# Altium schematic sheet ECAT0_(IN).SchDoc(ECAT0_(IN))
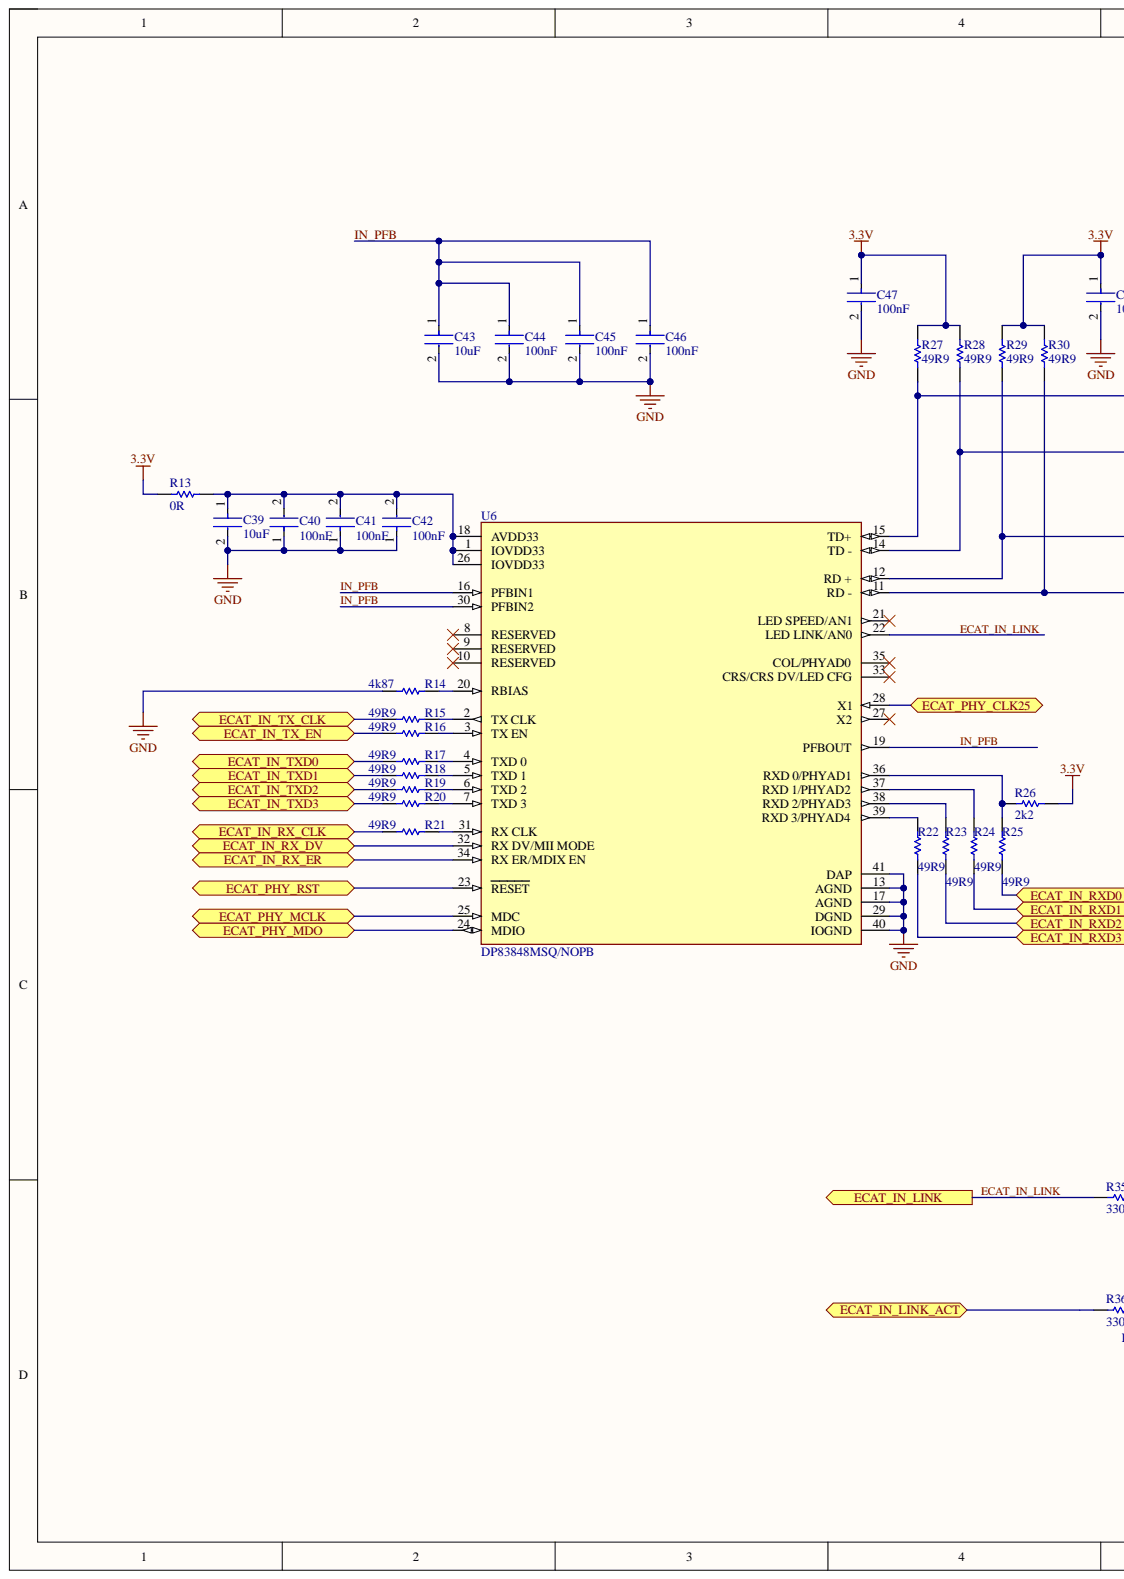
[diagram p0: ECAT0_, left]
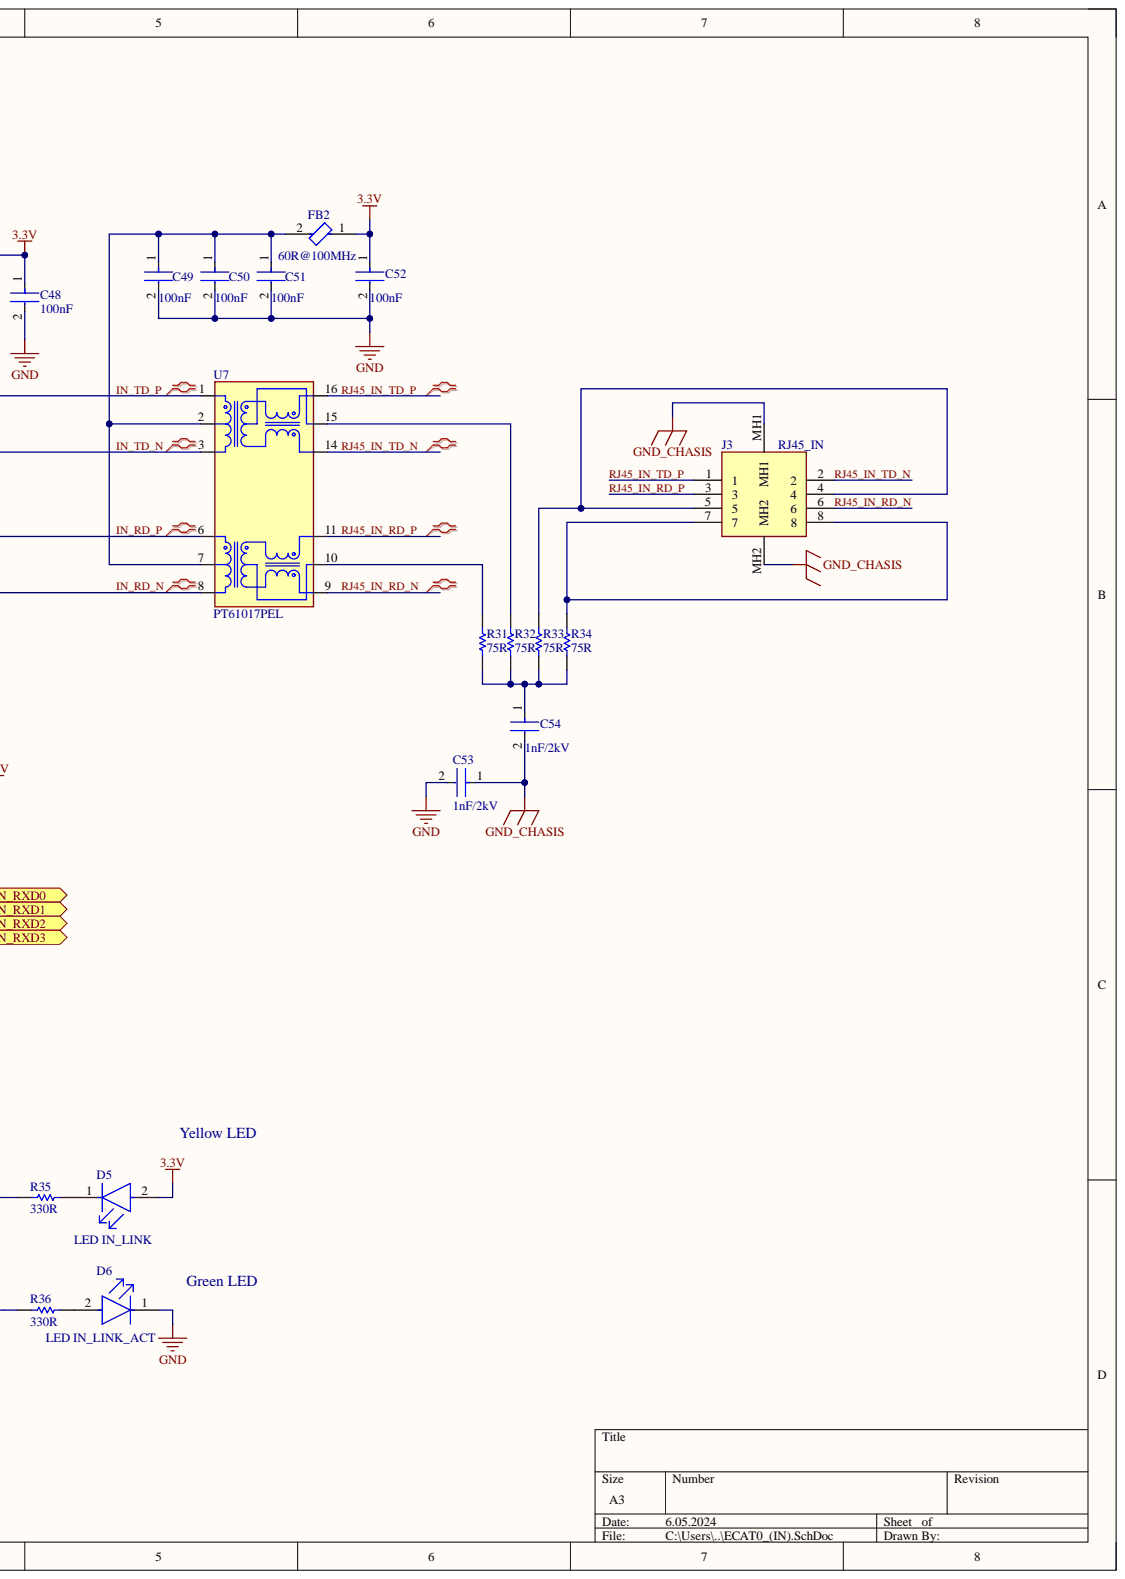
[diagram p1: ECAT0_, right]

## Parts
C39  10uF  [FP-C0805C-DN-MFG]
C40  100nF  [FP-C0805C-DN-MFG]
C41  100nF  [FP-C0805C-DN-MFG]
C42  100nF  [FP-C0805C-DN-MFG]
C43  10uF  [FP-C0805C-DN-MFG]
C44  100nF  [FP-C0805C-DN-MFG]
C45  100nF  [FP-C0805C-DN-MFG]
C46  100nF  [FP-C0805C-DN-MFG]
C47  100nF  [FP-C0805C-DN-MFG]
C48  100nF  [FP-C0805C-DN-MFG]
C49  100nF  [FP-C0805C-DN-MFG]
C50  100nF  [FP-C0805C-DN-MFG]
C51  100nF  [FP-C0805C-DN-MFG]
C52  100nF  [FP-C0805C-DN-MFG]
C53  1nF/2kV  [FP-CC1206-0_3-1_45-IPC_C]
C54  1nF/2kV  [FP-CC1206-0_3-1_45-IPC_C]
D5  LED IN_LINK  [FP-LTST-C191GKT-MFG]
D6  LED IN_LINK_ACT  Color: Green  [FP-LTST-C191GKT-MFG]
FB2  60R@100MHz  [FP-BLM18-0_15-t0_8-IPC_B]
J3  RJ45_IN  [438600010]
R13  0R  [RESC1609X50X30NL8T20]
R14  4k87  [RESC2013X50X30LL20T20]
R15  49R9  [RESC2013X50X30LL20T20]
R16  49R9  [RESC2013X50X30LL20T20]
R17  49R9  [RESC2013X50X30LL20T20]
R18  49R9  [RESC2013X50X30LL20T20]
R19  49R9  [RESC2013X50X30LL20T20]
R20  49R9  [RESC2013X50X30LL20T20]
R21  49R9  [RESC2013X50X30LL20T20]
R22  49R9  [RESC2013X50X30LL20T20]
R23  49R9  [RESC2013X50X30LL20T20]
R24  49R9  [RESC2013X50X30LL20T20]
R25  49R9  [RESC2013X50X30LL20T20]
R26  2k2  [RESC2013X50X30LL20T20]
R27  49R9  [RESC2013X50X30LL20T20]
R28  49R9  [RESC2013X50X30LL20T20]
R29  49R9  [RESC2013X50X30LL20T20]
R30  49R9  [RESC2013X50X30LL20T20]
R31  75R  [RESC2013X50X30LL20T20]
R32  75R  [RESC2013X50X30LL20T20]
R33  75R  [RESC2013X50X30LL20T20]
R34  75R  [RESC2013X50X30LL20T20]
R35  330R  [RESC2013X50X30LL20T20]
R36  330R  [RESC2013X50X30LL20T20]
U6  DP83848MSQ/NOPB  [FP-RTA0040A-IPC_B]
U7  PT61017PEL  [PT61017PEL]

## Ports
ECAT_IN_LINK, ECAT_IN_LINK_ACT, ECAT_IN_RX_CLK, ECAT_IN_RX_DV, ECAT_IN_RX_ER, ECAT_IN_RXD0, ECAT_IN_RXD1, ECAT_IN_RXD2, ECAT_IN_RXD3, ECAT_IN_TX_CLK, ECAT_IN_TX_EN, ECAT_IN_TXD0, ECAT_IN_TXD1, ECAT_IN_TXD2, ECAT_IN_TXD3, ECAT_PHY_CLK25, ECAT_PHY_MCLK, ECAT_PHY_MDO, ECAT_PHY_RST

## Nets
3.3V: C47.1 C48.1 C52.1 D5.2 FB2.1 R13.2 R26.1 R27.2 R28.2 R29.2 R30.2
GND: C39.2 C40.1 C41.1 C42.1 C43.2 C44.2 C45.2 C46.2 C47.2 C48.2 C49.2 C50.2 C51.2 C52.2 C53.2 D6.1 R14.1 U6.13(AGND) U6.17(AGND) U6.29(DGND) U6.40(IOGND) U6.41(DAP)
GND_CHASIS: C53.1 C54.2 J3.MH1 J3.MH2
ECAT_IN_LINK: R35.1 U6.22(LED_LINK/AN0) ; port ECAT_IN_LINK
ECAT_IN_LINK_ACT: R36.1 ; port ECAT_IN_LINK_ACT
ECAT_IN_RX_CLK: R21.1 ; port ECAT_IN_RX_CLK
ECAT_IN_RX_DV: U6.32(RX_DV/MII_MODE) ; port ECAT_IN_RX_DV
ECAT_IN_RX_ER: U6.34(RX_ER/MDIX_EN) ; port ECAT_IN_RX_ER
ECAT_IN_RXD0: R25.1 ; port ECAT_IN_RXD0
ECAT_IN_RXD1: R24.1 ; port ECAT_IN_RXD1
ECAT_IN_RXD2: R23.1 ; port ECAT_IN_RXD2
ECAT_IN_RXD3: R22.1 ; port ECAT_IN_RXD3
ECAT_IN_TX_CLK: R15.1 ; port ECAT_IN_TX_CLK
ECAT_IN_TX_EN: R16.1 ; port ECAT_IN_TX_EN
ECAT_IN_TXD0: R17.1 ; port ECAT_IN_TXD0
ECAT_IN_TXD1: R18.1 ; port ECAT_IN_TXD1
ECAT_IN_TXD2: R19.1 ; port ECAT_IN_TXD2
ECAT_IN_TXD3: R20.1 ; port ECAT_IN_TXD3
ECAT_PHY_CLK25: U6.28(X1) ; port ECAT_PHY_CLK25
ECAT_PHY_MCLK: U6.25(MDC) ; port ECAT_PHY_MCLK
ECAT_PHY_MDO: U6.24(MDIO) ; port ECAT_PHY_MDO
ECAT_PHY_RST: U6.23(RESET) ; port ECAT_PHY_RST
IN_PFB: C43.1 C44.1 C45.1 C46.1 U6.16(PFBIN1) U6.19(PFBOUT) U6.30(PFBIN2)
IN_RD_N: R30.1 U6.11(RD_-) U7.8
IN_RD_P: R29.1 U6.12(RD_+) U7.6
IN_TD_N: R28.1 U6.14(TD_-) U7.3
IN_TD_P: R27.1 U6.15(TD+) U7.1
RJ45_IN_RD_N: J3.6 U7.9
RJ45_IN_RD_P: J3.3 U7.11
RJ45_IN_TD_N: J3.2 U7.14
RJ45_IN_TD_P: J3.1 U7.16
NetC39_1: C39.1 C40.2 C41.2 C42.2 R13.1 U6.1(IOVDD33) U6.18(AVDD33) U6.26(IOVDD33)
NetC49_1: C49.1 C50.1 C51.1 FB2.2 U7.2 U7.7
NetC54_1: C54.1 R31.1 R32.1 R33.1 R34.1
NetD5_1: D5.1 R35.2
NetD6_2: D6.2 R36.2
NetJ3_4: J3.4 J3.5 R33.2
NetJ3_7: J3.7 J3.8 R34.2
NetR14_2: R14.2 U6.20(RBIAS)
NetR15_2: R15.2 U6.2(TX_CLK)
NetR16_2: R16.2 U6.3(TX_EN)
NetR17_2: R17.2 U6.4(TXD_0)
NetR18_2: R18.2 U6.5(TXD_1)
NetR19_2: R19.2 U6.6(TXD_2)
NetR20_2: R20.2 U6.7(TXD_3)
NetR21_2: R21.2 U6.31(RX_CLK)
NetR22_2: R22.2 U6.39(RXD_3/PHYAD4)
NetR23_2: R23.2 U6.38(RXD_2/PHYAD3)
NetR24_2: R24.2 U6.37(RXD_1/PHYAD2)
NetR25_2: R25.2 R26.2 U6.36(RXD_0/PHYAD1)
NetR31_2: R31.2 U7.10
NetR32_2: R32.2 U7.15

Not connected: U6 8(RESERVED) 9(RESERVED) 10(RESERVED) 21(LED_SPEED/AN1) 27(X2) 33(CRS/CRS_DV/LED_CFG) 35(COL/PHYAD0)

## Library data of the parts
C39-C52 = CMP-2007-00279-2: CAP CER 0.1UF 50V X7R 0805; Manufacturer: KEMET; Manufacturer Part Number: C0805C104K5RACTU; Capacitance: 0.10 μF; Material: Ceramic; Temperature Coefficient: 30ppm/°C; Tolerance: 0.1; Value: 0.10 μF; Automotive: No; Category: Cap; Component Kind: Standard; ComponentLink2Description: Datasheet; ComponentLink2URL: https://content.kemet.com/datasheets/KEM_C1003_C0G_SMD.pdf; Device Class L1: Passive Components; Device Class L2: Capacitors; Device Class L3: Ceramic Capacitors; Digikey Description: CAP CER 0.1UF 50V X7R 0805; Height: 0.88mm; Ipc Land Pattern Name: CAPC200125X78; Lead Free: Yes; Library Name: Altium Content Vault; Mouser Description: Cap Ceramic 0.1uF 50V X7R 10% SMD 2.0 x 1.2 mm 125 C Paper T/R; Octopart: https://octopart.com/c0805c104k5ractu-kemet-143926; Package: C0805C-DN; RoHS: TRUE; Temperature: -55°C to +125°C; Temperature Characteristic: X7R; Temperature Range High: +125°C; Temperature Range Low: -55°C; Voltage Rating: 50 V
C53 C54 = CMP-2000-05436-2: 1nF/2kV/1206; Capacitance: 1nF; Dielectric: X7R; Dissipation Factor: 2.5%; Insulation Resistance: 10GR; Tolerance: 10%; Voltage: 2kV; Case Code (Imperial): 1206; Case Code (Metric): 3216; Case/Package: 1206; Component Kind: Standard; Construction: Flat; Depth: 1.6mm; Height: 1.25mm; Lead Free: Lead Free; Length: 3.2mm; Library Name: Altium Content Vault; Max Operating Temperature: 125°C; Min Operating Temperature: -55°C; Mount: Surface Mount; Number of Pins: 2; Package Quantity: 1; Packaging: Tape and Reel; Radiation Hardening: No; Resistor Type: Ceramic; RoHS Compliant: Yes; Series: CC; Termination: SMD/SMT; Thickness: 0.057inch; Voltage Rating: 2kV; Voltage Rating (DC): 2kV; Width: 0.063inch
D5 = CMP-22017-000029-1: KPHD-1608LVSYCK; Color: Green; Dominant Wavelength: 569nm; Forward Current: 30mA; Forward Voltage: 2.1V; Lens Color: Colorless; Lens Style: Clear; Luminous Intensity: 12mcd; Number of Elements: 1; Power Dissipation: 100mW; Reverse Voltage: 5V; Test Current: 20mA; Viewing Angle: 130°; Wavelength: 565nm; Case Code (Imperial): 0603; Case Code (Metric): 1608; Case/Package: 0603; Component Kind: Standard; Depth: 0.8mm; Height: 0.55mm; Illumination Color: Green; Lead Free: Lead Free; Length: 1.6mm; Lens Transparency: Clear; Library Name: Altium Content Vault; Max Operating Temperature: 85°C; Min Operating Temperature: -55°C; Mount: Surface Mount; Number of LEDs: 1; Number of Pins: 2; Package Quantity: 1; Packaging: Tape and Reel; Power Rating: 100mW; Radiation Hardening: No; Width: 0.8mm
D6 = CMP-22017-000029-1: KPHD-1608LVCGCK; Dominant Wavelength: 569nm; Forward Current: 30mA; Forward Voltage: 2.1V; Lens Color: Colorless; Lens Style: Clear; Luminous Intensity: 12mcd; Number of Elements: 1; Power Dissipation: 100mW; Reverse Voltage: 5V; Test Current: 20mA; Viewing Angle: 130°; Wavelength: 565nm; Case Code (Imperial): 0603; Case Code (Metric): 1608; Case/Package: 0603; Component Kind: Standard; Depth: 0.8mm; Height: 0.55mm; Illumination Color: Green; Lead Free: Lead Free; Length: 1.6mm; Lens Transparency: Clear; Library Name: Altium Content Vault; Max Operating Temperature: 85°C; Min Operating Temperature: -55°C; Mount: Surface Mount; Number of LEDs: 1; Number of Pins: 2; Package Quantity: 1; Packaging: Tape and Reel; Power Rating: 100mW; Radiation Hardening: No; Width: 0.8mm
FB2 = CMP-0686-00575-3: Chip Ferrite Bead, 0603, 60Ω @ 100MHz, 0.1Ω, , 1A; Current Rating: 500mA; DC Resistance (DCR): 100mR; ESR (Equivalent Series Resistance): 60R; Material: Steel; Number of Circuits: 1; Shielding: Unshielded; Tolerance: 25%; Case Code (Imperial): 0603; Case Code (Metric): 1608; Case/Package: 0603; Component Kind: Standard; Core Material: Ferrite; Depth: 0.8mm; Frequency: 100MHz; Height: 0.8mm; Impedance: 60R; Lead Free: Lead Free; Length: 1.6mm; Library Name: Altium Content Vault; Max Current Rating: 500mA; Max DC Current: 500mA; Max Operating Temperature: 125°C; Min Operating Temperature: -55°C; Number of Lines: 1; Number of Pins: 2; Package Quantity: 1; Packaging: Tape and Reel; REACH SVHC: No SVHC; Resistance: 100mR; RoHS Compliant: Yes; Termination: SMD/SMT; Test Frequency: 100MHz; Width: 0.8mm
J3 = 43860-0010: Modular Jack Right Angle 8/8 RJ45 Invert Molex Cat3 RJ45 Modular Jack Socket, Right Angle, Through Hole 43860  43860-0010; Geometry.Height: 13.79mm; Component Kind: Standard; Datasheet Link: https://www.molex.com/webdocs/datasheets/pdf/en-us/0438600010_MODULAR_JACKS_PLUG.pdf; Library Name: 43860-0010_RJ45.SchLib; Manufacturer_Name: Molex; Manufacturer_Part_Number: 43860-0010; Mouser Part Number: 538-43860-0010; Mouser Price/Stock: https://www.mouser.co.uk/ProductDetail/Molex/43860-0010?qs=qjii0NTOo%252B4EzowI%2FkFJuQ%3D%3D
R13 = CMP-2000-03816-5: 0603; Manufacturer: Vishay Semiconductor; Voltage Rating: 75 V; Component Kind: Standard; ComponentLink1Description: Manufacturer URL; ComponentLink1URL: http://www.vishay.com/; ComponentLink2Description: Datasheet; ComponentLink2URL: http://www.vishay.com/docs/20035/dcrcwe3.pdf; DatasheetVersion: 06/2012; Height: 0.45 mm; Length: 1.55 mm; Library Name: Altium Content Vault; Max Operating Temperature: 155 degC; Min Operating Temperature: -55 degC; Mount: Surface Mount; Mounting Technology: Surface Mount; Package Quantity: 5000; PackageDescription: 2-Pin Surface Mount Device, Body 1.575 x 0.85 mm; PackageReference: 0603; Packaging: Tape and Reel; Pins: 2; REACH SVHC: Unknown; RoHSCompliant: true
R14-R36 = CMP-2001-04896-1: 0805; Manufacturer: Vishay Dale; Part Number: CRCW08050000Z0EA; Component Kind: Standard; Library Name: Altium Content Vault
U6 = CMP-0058-00351-5: IC TRANSCEIVER 1/1 40WQFN; Cable Length: 137m; Data Rate: 100Mbps; Interface: Serial; Max Power Dissipation: 264mW; Max Supply Voltage: 3.6V; Min Supply Voltage: 3V; Nominal Supply Current: 92mA; Number of Channels: 1; Operating Supply Voltage: 3.3V; Component Kind: Standard; Height: 0.8mm; Lead Free: Lead Free; Length: 4.6mm; Library Name: Altium Content Vault; Max Operating Temperature: 70°C; Min Operating Temperature: 0°C; Mount: Surface Mount; Number of Pins: 40; Number of Ports: 1; Number of Transceivers: 1; Package Quantity: 250; Packaging: Tape and Reel; Radiation Hardening: No; REACH SVHC: No SVHC; RoHS Compliant: Yes; Series: DP83848M; Supply Current: 92mA; Termination: SMD/SMT; Width: 4.6mm
U7 = PT61017PEL: 350 µH LAN 10/100 Base-T Pulse Transformer 1CT:1CT Surface Mount    PT61017PEL; MF: Bourns; Availability: In Stock; Check_prices: https://www.snapeda.com/parts/PT61017PEL/Bourns/view-part/?ref=eda; Component Kind: Standard; Library Name: OTHER.SchLib; MP: PT61017PEL; Package: SMD-16 Bourns; Price: None; Purchase-URL: https://pricing.snapeda.com/search/part/PT61017PEL/?ref=eda; SnapEDA_Link: https://www.snapeda.com/parts/PT61017PEL/Bourns/view-part/?ref=snap
